# Altium schematic sheet STM32F103TBU_OSD.SchDoc
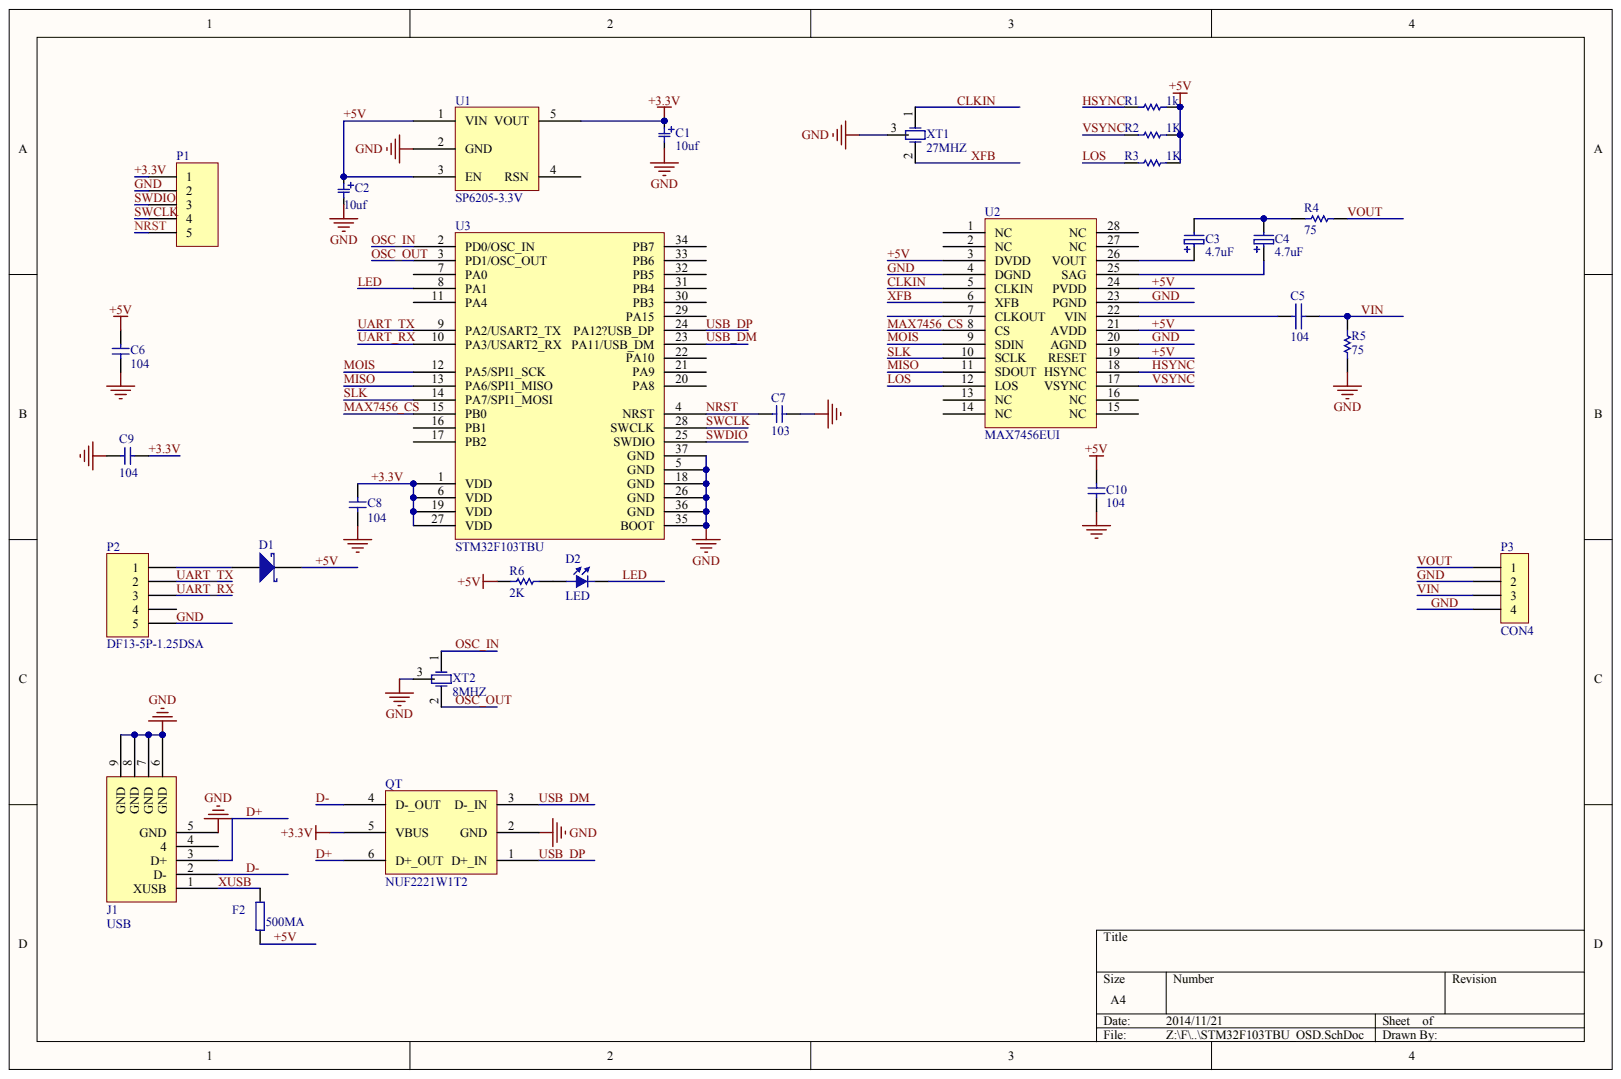
[diagram p0: STM32F103TBU_OSD.SchDoc]

## Parts
C1  10uf
C2  10uf
C3  4.7uF
C4  4.7uF
C5  104
C6  104
C7  103
C8  104
C9  104
C10  104
D1  D Schottky  (value not drawn)
D2  LED
F2  500MA
J1  USB
P1  (no value)
P2  DF13-5P-1.25DSA
P3  CON4
QT  NUF2221W1T2
R1  1k
R2  1K
R3  1K
R4  75
R5  75
R6  2K
U1  SP6205-3.3V
U2  MAX7456EUI
U3  STM32F103TBU
XT1  27MHZ
XT2  8MHZ

## Nets
+3.3V: C1.2 C8.1 C9.2 P1.1 QT.5(VBUS) U1.5(VOUT) U3.1(VDD) U3.6(VDD) U3.19(VDD) U3.27(VDD)
+5V: C2.2 C6.1 C10.1 D1.2 F2.1 R1.1 R2.2 R3.2 R6.2 U1.1(VIN) U1.3(EN) U2.3(DVDD) U2.19(RESET) U2.21(AVDD) U2.24(PVDD)
CLKIN: U2.5(CLKIN) XT1.1
D+: J1.3(D+) QT.6(D+_OUT)
D-: J1.2(D-) QT.4(D-_OUT)
GND: C1.1 C2.1 C6.2 C7.1 C8.2 C9.1 C10.2 J1.5(GND) J1.6(GND) J1.7(GND) J1.8(GND) J1.9(GND) P1.2 P2.5 P3.2 P3.4 QT.2(GND) R5.1 U1.2(GND) U2.4(DGND) U2.20(AGND) U2.23(PGND) U3.5(GND) U3.18(GND) U3.26(GND) U3.35(BOOT) U3.36(GND) U3.37(GND) XT1.3 XT2.3
HSYNC: R1.2 U2.18(HSYNC)
LED: D2.2 U3.8(PA1)
LOS: R3.1 U2.12(LOS)
MAX7456_CS: U2.8(CS) U3.15(PB0)
MISO: U2.11(SDOUT) U3.13(PA6/SPI1_MISO)
MOIS: U2.9(SDIN) U3.12(PA5/SPI1_SCK)
NRST: C7.2 P1.5 U3.4(NRST)
OSC_IN: U3.2(PD0/OSC_IN) XT2.1
OSC_OUT: U3.3(PD1/OSC_OUT) XT2.2
SLK: U2.10(SCLK) U3.14(PA7/SPI1_MOSI)
SWCLK: P1.4 U3.28(SWCLK)
SWDIO: P1.3 U3.25(SWDIO)
UART_RX: P2.3 U3.10(PA3/USART2_RX)
UART_TX: P2.2 U3.9(PA2/USART2_TX)
USB_DM: QT.3(D-_IN) U3.23(PA11/USB_DM)
USB_DP: QT.1(D+_IN) U3.24(PA12?USB_DP)
VIN: C5.2 P3.3 R5.2
VOUT: P3.1 R4.2
VSYNC: R2.1 U2.17(VSYNC)
XFB: U2.6(XFB) XT1.2
XUSB: F2.2 J1.1(XUSB)
NetC3_1: C3.1 U2.26(VOUT)
NetC3_2: C3.2 C4.2 R4.1
NetC4_1: C4.1 U2.25(SAG)
NetC5_1: C5.1 U2.22(VIN)
NetD1_1: D1.1 P2.1
NetD2_1: D2.1 R6.1

Not connected: J1 4; P2 4; U1 4(RSN); U2 1(NC) 2(NC) 7(CLKOUT) 13(NC) 14(NC) 15(NC) 16(NC) 27(NC) 28(NC); U3 7(PA0) 11(PA4) 16(PB1) 17(PB2) 20(PA8) 21(PA9) 22(PA10) 29(PA15) 30(PB3) 31(PB4) 32(PB5) 33(PB6) 34(PB7)
